# Altium schematic sheet DDS Rev2.SchDoc("DDS Rev2")
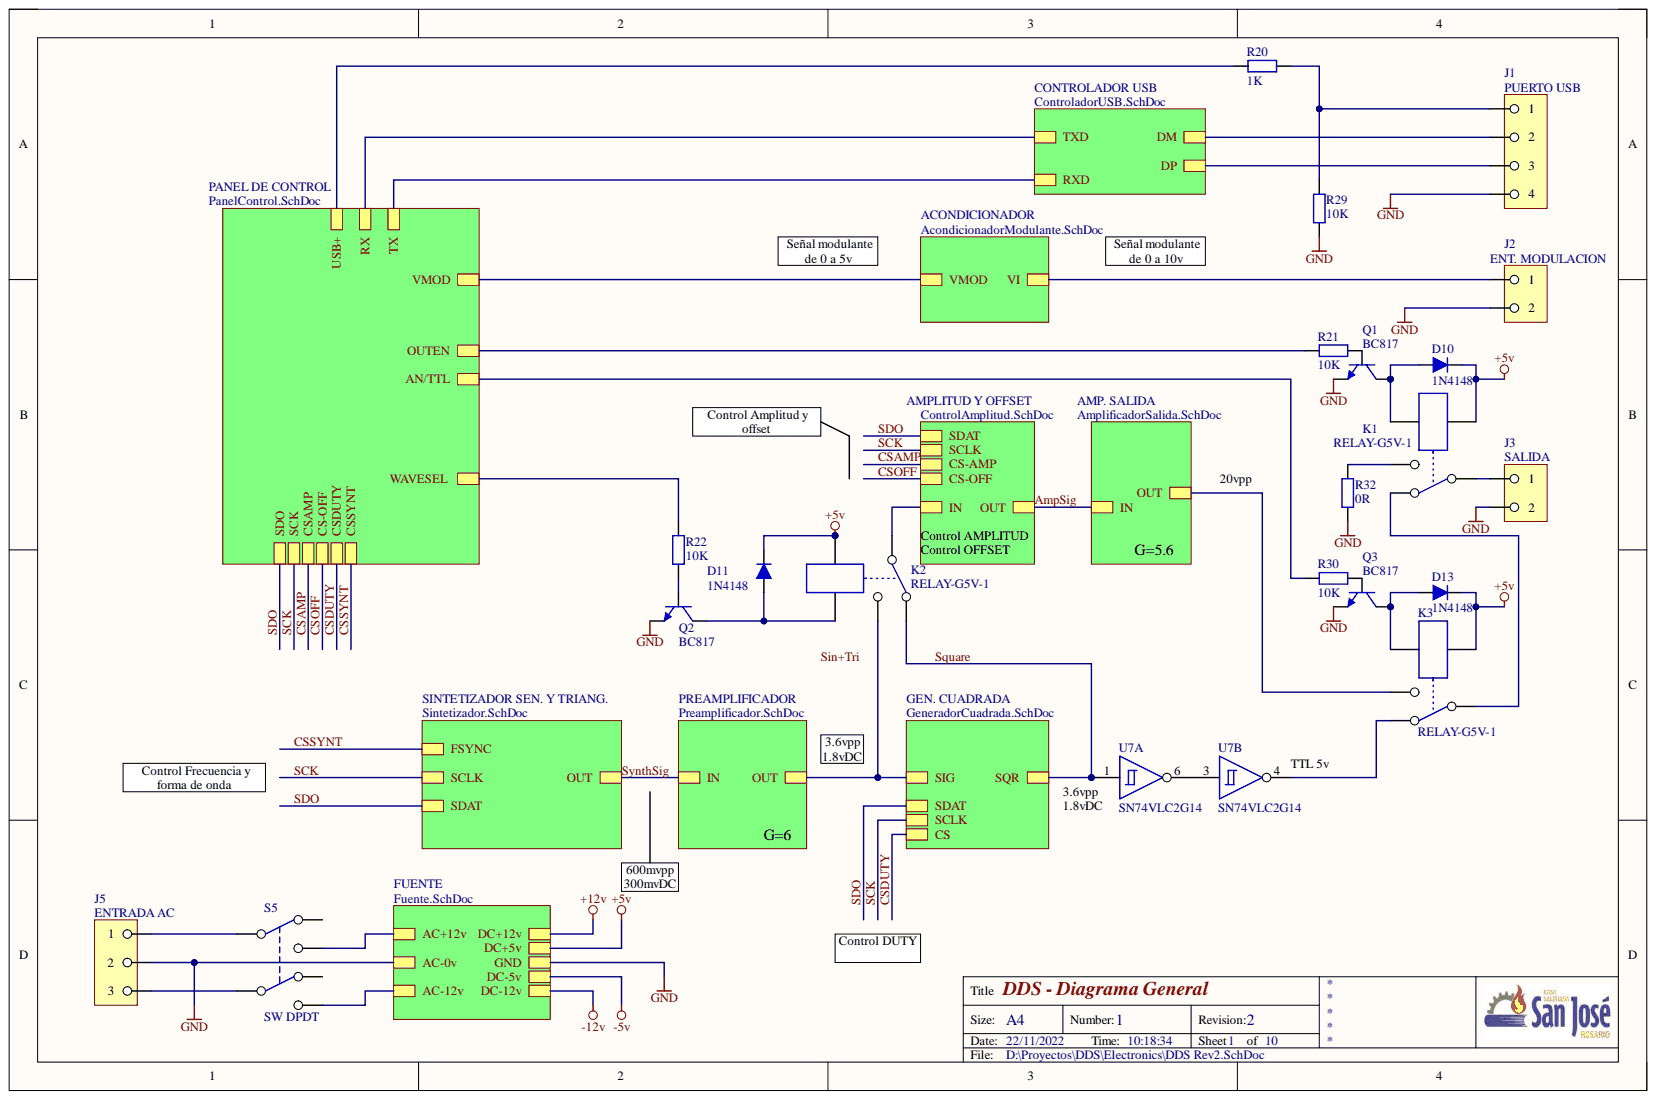
[diagram p0: DDS Rev2.SchDoc]

## Parts
D10  1N4148  [DAXIAL0.3]
D11  1N4148  [DAXIAL0.3]
D13  1N4148  [DAXIAL0.3]
J1  PUERTO USB  [HDR1x4]
J2  ENT. MODULACION  [BNC RECT]
J3  SALIDA  [BNC RECT]
J5  ENTRADA AC  [BORNERA_3]
K1  RELAY-G5V-1  [G5V-1]
K2  RELAY-G5V-1  [G5V-1]
K3  RELAY-G5V-1  [G5V-1]
Q1  BC817  [SOT23]
Q2  BC817  [SOT23]
Q3  BC817  [SOT23]
R20  1K  [0805]
R21  10K  [0805]
R22  10K  [0805]
R29  10K  [0805]
R30  10K  [0805]
R32  0R  [0805]
S5  SW DPDT  [SWITCH2]
U7  SN74VLC2G14  [SOT23-6]  (parts A,B)

## Nets
+5v: D10.2 D11.2 D13.2 K1.1 K2.1 K3.1 U7.5
GND: J1.4 J2.2 J3.2 J5.2 Q1.2 Q2.2 Q3.2 R29.2 R32.2 U7.2
Square: K2.5 U7.1
NetD10_1: D10.1 K1.2 Q1.3
NetD11_1: D11.1 K2.2 Q2.3
NetD13_1: D13.1 K3.2 Q3.3
NetJ1_1: J1.1 R20.1 R29.1
NetJ1_2: J1.2
NetJ1_3: J1.3
NetJ2_1: J2.1
NetJ3_1: J3.1 K1.3
NetJ5_1: J5.1 S5.1
NetJ5_3: J5.3 S5.5
NetK1_4: K1.4 R32.1
NetK1_5: K1.5 K3.3
NetK2_3: K2.3
NetK2_4: K2.4
NetK3_4: K3.4
NetK3_5: K3.5 U7.4
NetQ1_1: Q1.1 R21.1
NetQ2_1: Q2.1 R22.1
NetQ3_1: Q3.1 R30.1
NetR20_2: R20.2
NetR21_2: R21.2
NetR22_2: R22.2
NetR30_2: R30.2
NetS5_2: S5.2
NetS5_3: S5.3
NetS5_4: S5.4
NetS5_6: S5.6
NetU7_3: U7.3 U7.6
+12v:
-5v:
-12v:
AmpSig:
CSAMP:
CSDUTY:
CSOFF:
CSSYNT:
N00001:
N00002:
N00003:
SCK:
SDO:
Sin+Tri:
SynthSig:

## Library data of the parts
D10 D11 D13 = DIODE: Component Kind: Standard; Description: Diode; Library Name: Misc.IntLib; Mount: Through-hole; Value: 1N4148
J1 = CONECTOR 1X4: Conector; Component Kind: Standard; Library Name: Misc.IntLib; Mount: Through-hole
J2 J3 = CONECTOR 1X2: Conector; Component Kind: Standard; Library Name: Misc.IntLib; Mount: Through-hole; Remark: https://www.digikey.com/product-detail/en/molex/0731711900/WM5517-ND/1465139
J5 = CONECTOR 1X3: Conector; Component Kind: Standard; Library Name: Misc.IntLib; Mount: Through-hole
Q1-Q3 = BC817: Transistor; Component Kind: Standard; Library Name: Misc.IntLib; Mount: SMD; Package: SOT23
R20-R22 R29 R30 R32 = RES: Resistor; Power: 0.125W; Component Kind: Standard; Library Name: Misc.IntLib; Mount: SMD; Package: 0805
  R20: Value: 1K
  R21 R22 R29 R30: Value: 10K
  R32: Value: 0R
U7 = SN74LVC2G14: Integrated Circuit; Component Kind: Standard; Library Name: Schematics.SchLib; Mount: Through-Hole
K1-K3 = RELAY-G5V-1: Component Kind: Standard; Library Name: Misc.IntLib
S5 = SW DPDT: Component Kind: Standard; Library Name: Misc.IntLib
